# Altium schematic sheet PIXRACER-SERIALS-R12.SchDoc(PIXRACER-SERIALS-R12)
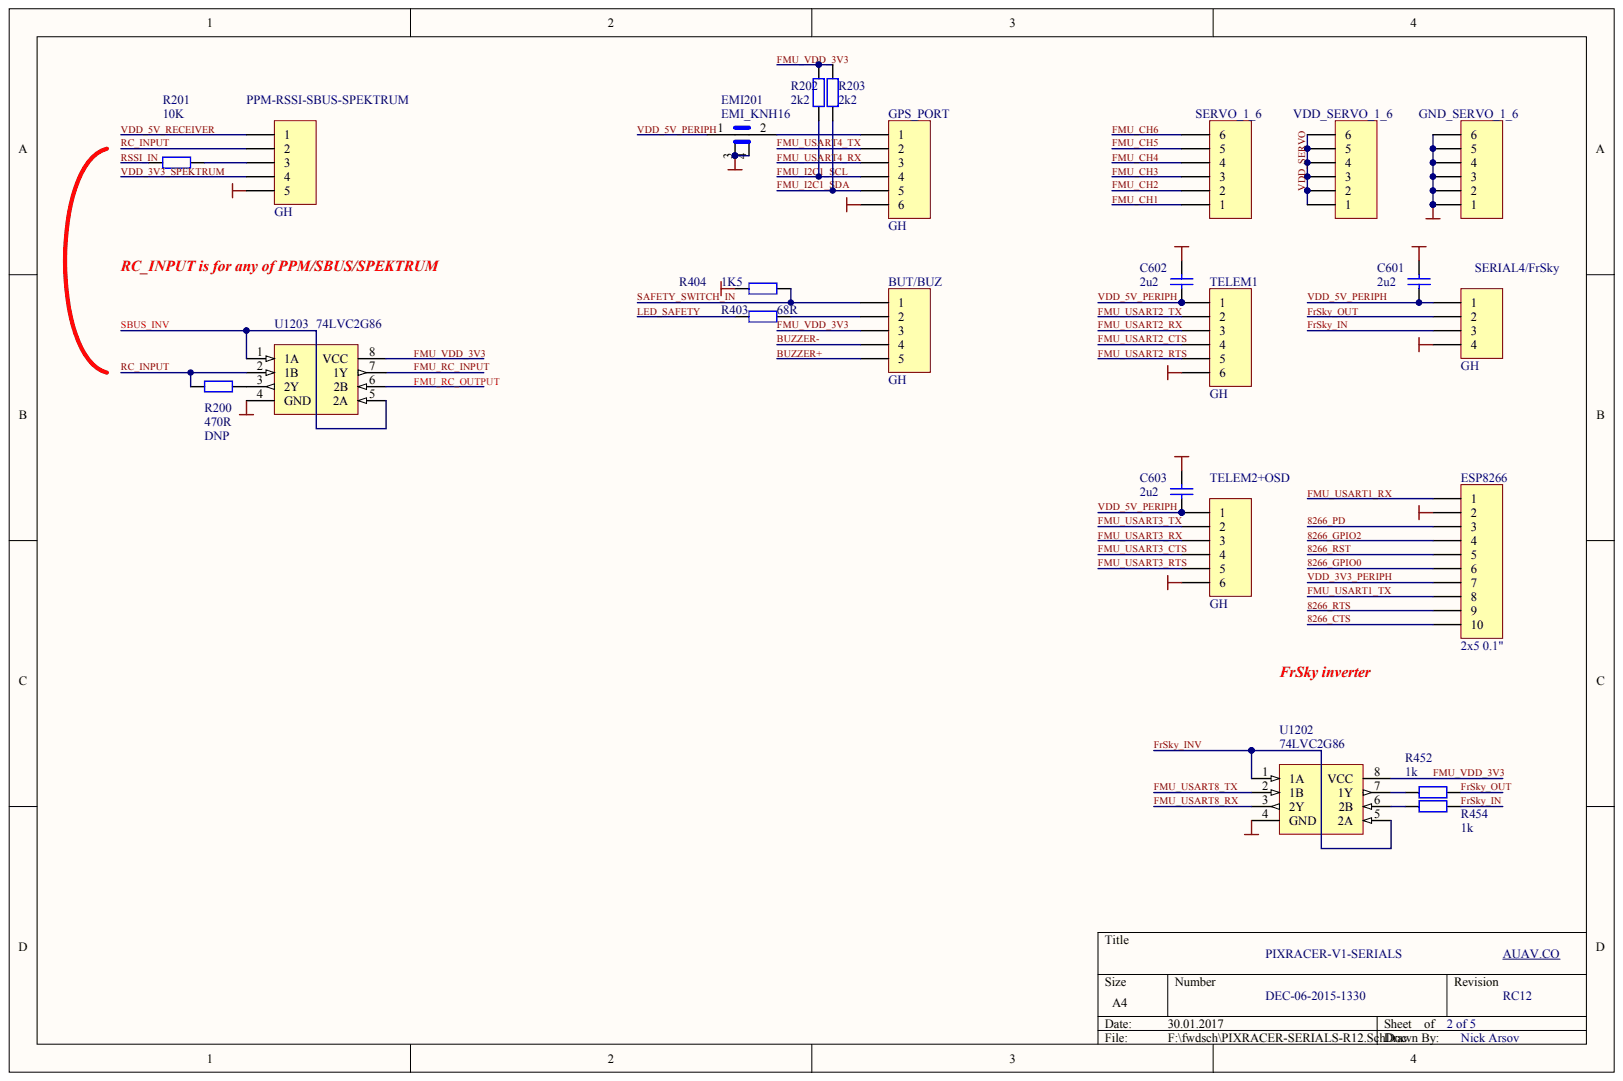
[diagram p0: PIXRACER-SERIALS-R12.SchDoc]

## Parts
BUT/BUZ  GH
C601  2u2
C602  2u2
C603  2u2
EMI201  EMI_KNH16
ESP8266  2x5 0.1"
GND_SERVO_1_6  Header 6  (value not drawn)
GPS_PORT  GH
PPM-RSSI-SBUS-SPEKTRUM  GH
R200  470R
R201  10K
R202  2k2
R203  2k2
R403  68R
R404  1K5
R452  1k
R454  1k
SERIAL4/FrSky  GH
SERVO_1_6  Header 6  (value not drawn)
TELEM1  GH
TELEM2+OSD  GH
U1202  74LVC2G86
U1203  74LVC2G86
VDD_SERVO_1_6  Header 6  (value not drawn)

## Nets
GND: C601.2 C602.2 C603.2 EMI201.3 EMI201.4 ESP8266.2 GND_SERVO_1_6.1 GND_SERVO_1_6.2 GND_SERVO_1_6.3 GND_SERVO_1_6.4 GND_SERVO_1_6.5 GND_SERVO_1_6.6 GPS_PORT.6 PPM-RSSI-SBUS-SPEKTRUM.5 R404.2 SERIAL4/FrSky.4 TELEM1.6 TELEM2+OSD.6 U1202.4(GND) U1203.4(GND)
8266_CTS: ESP8266.10
8266_GPIO0: ESP8266.6
8266_GPIO2: ESP8266.4
8266_PD: ESP8266.3
8266_RST: ESP8266.5
8266_RTS: ESP8266.9
BUZZER+: BUT/BUZ.5
BUZZER-: BUT/BUZ.4
FMU_CH1: SERVO_1_6.1
FMU_CH2: SERVO_1_6.2
FMU_CH3: SERVO_1_6.3
FMU_CH4: SERVO_1_6.4
FMU_CH5: SERVO_1_6.5
FMU_CH6: SERVO_1_6.6
FMU_I2C1_SCL: GPS_PORT.4 R202.2
FMU_I2C1_SDA: GPS_PORT.5 R203.2
FMU_RC_INPUT: U1203.7(1Y)
FMU_RC_OUTPUT: U1203.6(2B)
FMU_USART1_RX: ESP8266.1
FMU_USART1_TX: ESP8266.8
FMU_USART2_CTS: TELEM1.4
FMU_USART2_RTS: TELEM1.5
FMU_USART2_RX: TELEM1.3
FMU_USART2_TX: TELEM1.2
FMU_USART3_CTS: TELEM2+OSD.4
FMU_USART3_RTS: TELEM2+OSD.5
FMU_USART3_RX: TELEM2+OSD.3
FMU_USART3_TX: TELEM2+OSD.2
FMU_USART4_RX: GPS_PORT.3
FMU_USART4_TX: GPS_PORT.2
FMU_USART8_RX: U1202.3(2Y)
FMU_USART8_TX: U1202.2(1B)
FMU_VDD_3V3: BUT/BUZ.3 R202.1 R203.1 U1202.8(VCC) U1203.8(VCC)
FRSKY_IN: R454.1 SERIAL4/FrSky.3
FRSKY_INV: U1202.1(1A) U1202.5(2A)
FRSKY_OUT: R452.1 SERIAL4/FrSky.2
LED_SAFETY: R403.2
RC_INPUT: PPM-RSSI-SBUS-SPEKTRUM.2 R200.2 U1203.2(1B)
RSSI_IN: R201.2
SAFETY_SWITCH_IN: BUT/BUZ.1 R404.1
SBUS_INV: U1203.1(1A) U1203.5(2A)
VDD_3V3_PERIPH: ESP8266.7
VDD_3V3_SPEKTRUM: PPM-RSSI-SBUS-SPEKTRUM.4
VDD_5V_PERIPH: C601.1 C602.1 C603.1 EMI201.1 SERIAL4/FrSky.1 TELEM1.1 TELEM2+OSD.1
VDD_5V_RECEIVER: PPM-RSSI-SBUS-SPEKTRUM.1
VDD_SERVO: VDD_SERVO_1_6.1 VDD_SERVO_1_6.2 VDD_SERVO_1_6.3 VDD_SERVO_1_6.4 VDD_SERVO_1_6.5 VDD_SERVO_1_6.6
NetBUT/BUZ_2: BUT/BUZ.2 R403.1
NetEMI201_2: EMI201.2 GPS_PORT.1
NetPPM-RSSI-SBUS-SPEKTRUM_3: PPM-RSSI-SBUS-SPEKTRUM.3 R201.1
NetR200_1: R200.1 U1203.3(2Y)
NetR452_2: R452.2 U1202.7(1Y)
NetR454_2: R454.2 U1202.6(2B)

## Library data of the parts
C601-C603 = (no symbol name): Value: 100pF; Published: 8-Jun-2000; LatestRevisionDate: 17-Jul-2002; LatestRevisionNote: Re-released for DXP Platform.; PackageReference: RAD-0.3; Publisher: Altium Limited
R200 = (no symbol name): Value: 1K; REM: DNP; Published: 8-Jun-2000; LatestRevisionDate: 17-Jul-2002; LatestRevisionNote: Re-released for DXP Platform.; PackageReference: AXIAL-0.4; Publisher: Altium Limited
R201-R203 R403 R404 = (no symbol name): Value: 1K; Published: 8-Jun-2000; LatestRevisionDate: 17-Jul-2002; LatestRevisionNote: Re-released for DXP Platform.; PackageReference: AXIAL-0.4; Publisher: Altium Limited
  R202 R203: PackageDescription: Axial Device, Thru-Hole; 2 Leads; 0.4 in Pin Spacing
BUT/BUZ EMI201 ESP8266 GND_SERVO_1_6 GPS_PORT PPM-RSSI-SBUS-SPEKTRUM R452 R454 SERIAL4/FrSky SERVO_1_6 TELEM1 TELEM2+OSD U1202 U1203 VDD_SERVO_1_6 = (no symbol name)
  BUT/BUZ ESP8266 GND_SERVO_1_6 GPS_PORT PPM-RSSI-SBUS-SPEKTRUM SERIAL4/FrSky SERVO_1_6 TELEM1 TELEM2+OSD VDD_SERVO_1_6: LatestRevisionDate: 17-Jul-2002; LatestRevisionNote: Re-released for DXP Platform.
  R452 R454: Published: 8-Jun-2000; LatestRevisionDate: 17-Jul-2002; LatestRevisionNote: Re-released for DXP Platform.; PackageReference: AXIAL-0.4; PackageDescription: Axial Device, Thru-Hole; 2 Leads; 0.4 in Pin Spacing; Value: 1K
  BUT/BUZ ESP8266 GND_SERVO_1_6 GPS_PORT PPM-RSSI-SBUS-SPEKTRUM R452 R454 SERIAL4/FrSky SERVO_1_6 TELEM1 TELEM2+OSD VDD_SERVO_1_6: Publisher: Altium Limited
